# Altium schematic sheet conditioning_circuit.SchDoc
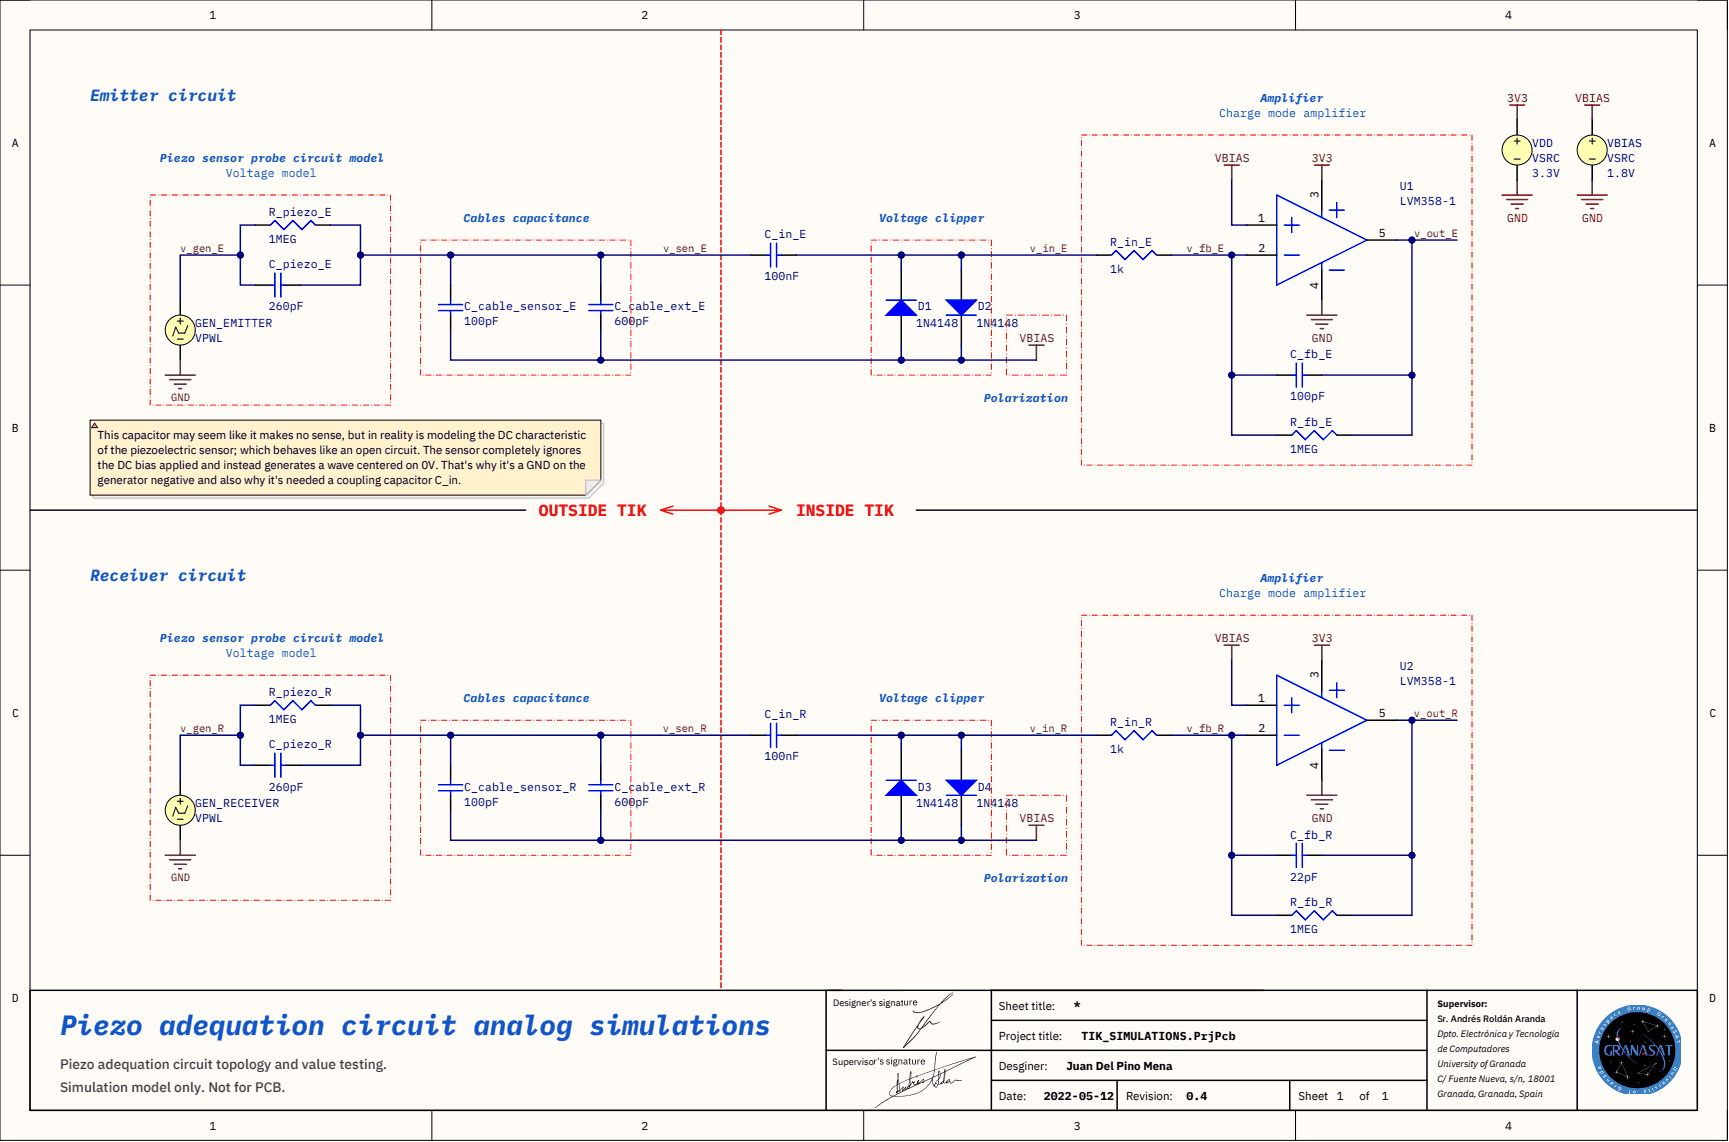
[diagram p0: conditioning_circuit.SchDoc]

## Parts
C_cable_ext_E  value 600pF  [C1206]  (hidden comment: Cap Semi)
C_cable_ext_R  value 600pF  [C1206]  (hidden comment: Cap Semi)
C_cable_sensor_E  value 100pF  [C1206]  (hidden comment: Cap Semi)
C_cable_sensor_R  value 100pF  [C1206]  (hidden comment: Cap Semi)
C_fb_E  value 100pF  [C1206]  (hidden comment: Cap Semi)
C_fb_R  value 22pF  [C1206]  (hidden comment: Cap Semi)
C_in_E  value 100nF  [C1206]  (hidden comment: Cap Semi)
C_in_R  value 100nF  [C1206]  (hidden comment: Cap Semi)
C_piezo_E  value 260pF  [C1206]  (hidden comment: Cap Semi)
C_piezo_R  value 260pF  [C1206]  (hidden comment: Cap Semi)
D1  1N4148  [DO-35]
D2  1N4148  [DO-35]
D3  1N4148  [DO-35]
D4  1N4148  [DO-35]
GEN_EMITTER  VPWL
GEN_RECEIVER  VPWL
R_fb_E  value 1MEG  [J1-0603]  (hidden comment: Res3)
R_fb_R  value 1MEG  [J1-0603]  (hidden comment: Res3)
R_in_E  value 1k  [J1-0603]  (hidden comment: Res3)
R_in_R  value 1k  [J1-0603]  (hidden comment: Res3)
R_piezo_E  value 1MEG  [J1-0603]  (hidden comment: Res3)
R_piezo_R  value 1MEG  [J1-0603]  (hidden comment: Res3)
U1  LMV358  (value not drawn)
U2  LMV358  (value not drawn)
VBIAS  VSRC  value 1.8V
VDD  VSRC  value 3.3V

## Nets
3V3: U1.3 U2.3 VDD.1
GND: GEN_EMITTER.2 GEN_RECEIVER.2 U1.4 U2.4 VBIAS.2 VDD.2
VBIAS: C_cable_ext_E.1 C_cable_ext_R.1 C_cable_sensor_E.1 C_cable_sensor_R.1 D1.1 D2.2 D3.1 D4.2 U1.1 U2.1 VBIAS.1
v_fb_E: C_fb_E.1 R_fb_E.1 R_in_E.2 U1.2
v_fb_R: C_fb_R.1 R_fb_R.1 R_in_R.2 U2.2
v_gen_E: C_piezo_E.1 GEN_EMITTER.1 R_piezo_E.1
v_gen_R: C_piezo_R.1 GEN_RECEIVER.1 R_piezo_R.1
v_in_E: C_in_E.2 D1.2 D2.1 R_in_E.1
v_in_R: C_in_R.2 D3.2 D4.1 R_in_R.1
v_out_E: C_fb_E.2 R_fb_E.2 U1.5
v_out_R: C_fb_R.2 R_fb_R.2 U2.5
v_sen_E: C_cable_ext_E.2 C_cable_sensor_E.2 C_in_E.1 C_piezo_E.2 R_piezo_E.2
v_sen_R: C_cable_ext_R.2 C_cable_sensor_R.2 C_in_R.1 C_piezo_R.2 R_piezo_R.2

## Library data of the parts
C_cable_ext_E C_cable_ext_R = Cap Semi: Capacitor (Semiconductor SIM Model); Value: 600pF; Component Kind: Standard; LatestRevisionDate: 29-May-2009; LatestRevisionNote: IPC-7351 Footprint Added.; Library Name: Miscellaneous Devices.IntLib; PackageDescription: Chip Capacitor; PackageReference: C1206; Published: 8-Jun-2000; Signal Integrity: Cap Semi; Simulation: CAP; Publisher: Altium Limited
C_cable_sensor_E C_cable_sensor_R C_fb_E = Cap Semi: Capacitor (Semiconductor SIM Model); Value: 100pF; Component Kind: Standard; LatestRevisionDate: 29-May-2009; LatestRevisionNote: IPC-7351 Footprint Added.; Library Name: Miscellaneous Devices.IntLib; PackageDescription: Chip Capacitor; PackageReference: C1206; Published: 8-Jun-2000; Signal Integrity: Cap Semi; Simulation: CAP; Publisher: Altium Limited
C_fb_R = Cap Semi: Capacitor (Semiconductor SIM Model); Value: 22pF; Component Kind: Standard; LatestRevisionDate: 29-May-2009; LatestRevisionNote: IPC-7351 Footprint Added.; Library Name: Miscellaneous Devices.IntLib; PackageDescription: Chip Capacitor; PackageReference: C1206; Published: 8-Jun-2000; Signal Integrity: Cap Semi; Simulation: CAP; Publisher: Altium Limited
C_in_E C_in_R = Cap Semi: Capacitor (Semiconductor SIM Model); Value: 100nF; Component Kind: Standard; LatestRevisionDate: 29-May-2009; LatestRevisionNote: IPC-7351 Footprint Added.; Library Name: Miscellaneous Devices.IntLib; PackageDescription: Chip Capacitor; PackageReference: C1206; Published: 8-Jun-2000; Signal Integrity: Cap Semi; Simulation: CAP; Publisher: Altium Limited
C_piezo_E C_piezo_R = Cap Semi: Capacitor (Semiconductor SIM Model); Value: 260pF; Component Kind: Standard; LatestRevisionDate: 29-May-2009; LatestRevisionNote: IPC-7351 Footprint Added.; Library Name: Miscellaneous Devices.IntLib; PackageDescription: Chip Capacitor; PackageReference: C1206; Published: 8-Jun-2000; Signal Integrity: Cap Semi; Simulation: CAP; Publisher: Altium Limited
D1-D4 = Diode 1N4148: High Conductance Fast Diode; Code_JEDEC: DO-204-AH; Component Kind: Standard; ComponentLink1Description: Manufacturer Link; ComponentLink1URL: http://www.fairchildsemi.com/; ComponentLink2Description: Datasheet; ComponentLink2URL: http://www.fairchildsemi.com/ds/1N%2F1N4148.pdf; DatasheetVersion: 1997; LatestRevisionDate: 17-Jul-2002; LatestRevisionNote: Re-released for DXP Platform.; Library Name: Miscellaneous Devices.IntLib; PackageDescription: Diode, Axial; Body 3.8 x 1.9 mm (LxDia. max), Lead Dia. 0.53 mm (max); PackageReference: DO-35; Published: 8-Jun-2000; Signal Integrity: 1N4148; Simulation: 1N4148; Publisher: Altium Limited
GEN_EMITTER GEN_RECEIVER = VPWL: Piecewise Linear Voltage Source; Note: PCB Footprint - Not required; Sim Note: To add model file containing time-current pairs of values, enter model location under "General Tab" in "Full Path" box.; Component Kind: Standard; LatestRevisionDate: 17-Jul-2002; LatestRevisionNote: Re-released for DXP Platform.; Library Name: Simulation Sources.IntLib; PackageReference: Not Applicable; Published: 8-Jun-2000; Simulation: VPWL; Publisher: Altium Limited
R_fb_E R_fb_R R_piezo_E R_piezo_R = Res3: Resistor; Value: 1MEG; Component Kind: Standard; LatestRevisionDate: 29-May-2009; LatestRevisionNote: IPC-7351 Footprint Added.; Library Name: Miscellaneous Devices.IntLib; PackageDescription: Chip Resistor; PackageReference: J1-0603; Published: 8-Jun-2000; Signal Integrity: Res; Simulation: RESISTOR; Publisher: Altium Limited
R_in_E R_in_R = Res3: Resistor; Value: 1k; Component Kind: Standard; LatestRevisionDate: 29-May-2009; LatestRevisionNote: IPC-7351 Footprint Added.; Library Name: Miscellaneous Devices.IntLib; PackageDescription: Chip Resistor; PackageReference: J1-0603; Published: 8-Jun-2000; Signal Integrity: Res; Simulation: RESISTOR; Publisher: Altium Limited
U1 U2 = LMV358: Part: LVM358-1; Component Kind: Standard; Simulation: lmv358; Library Name: TIK_SIM.SchLib
VBIAS = VSRC: Voltage Source; AC Magnitude: 1; AC Phase: 0; Note: PCB Footprint - Not required; Value: 1.8V; Component Kind: Standard; LatestRevisionDate: 30-July-2020; LatestRevisionNote: Re-released for DXP Platform.; Library Name: Simulation Generic Components.IntLib; PackageReference: Not Applicable; Published: 30-July-2020; Simulation: VSRC; Publisher: Altium Limited
VDD = VSRC: Voltage Source; AC Magnitude: 1; AC Phase: 0; Note: PCB Footprint - Not required; Value: 3.3V; Component Kind: Standard; LatestRevisionDate: 30-July-2020; LatestRevisionNote: Re-released for DXP Platform.; Library Name: Simulation Generic Components.IntLib; PackageReference: Not Applicable; Published: 30-July-2020; Simulation: VSRC; Publisher: Altium Limited
